# Altium schematic sheet 02-MCU.SchDoc
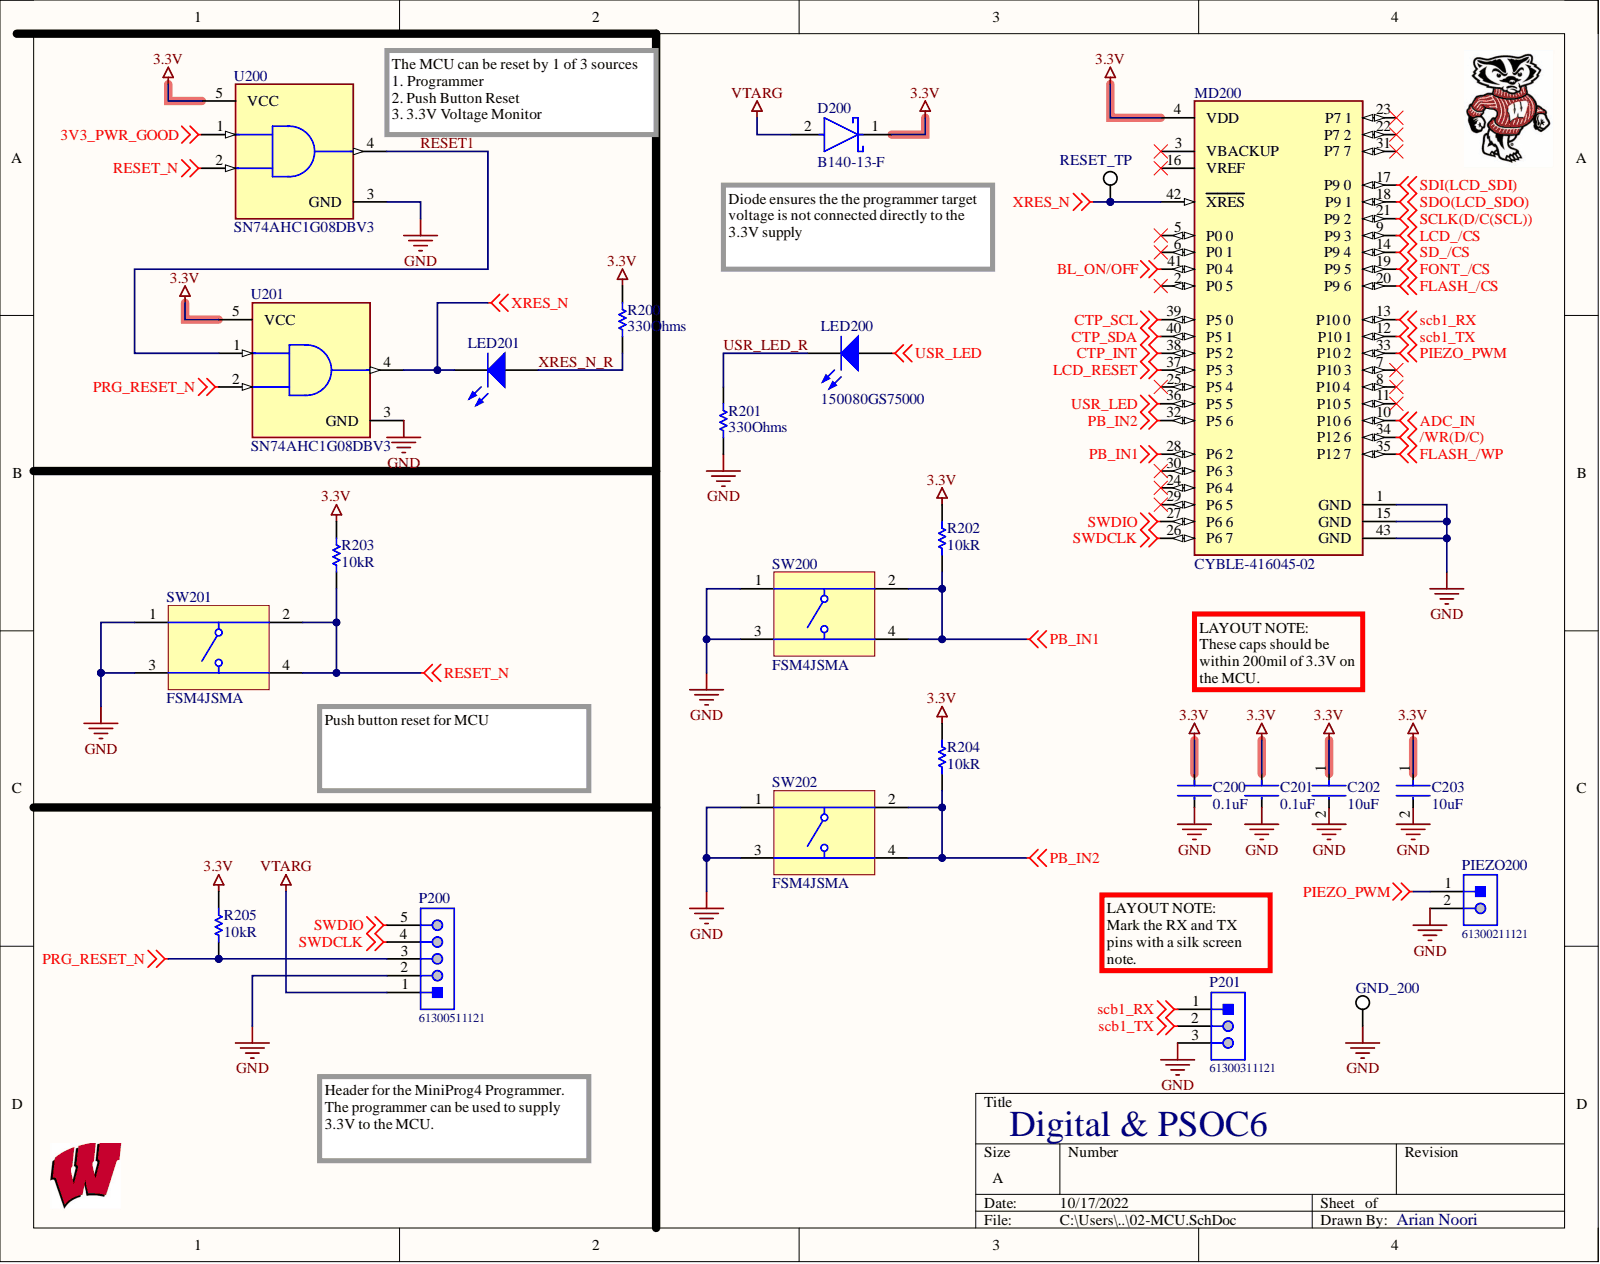
[diagram p0: 02-MCU.SchDoc]

## Parts
C200  0.1uF  [FP-CL21-IPC_C]
C201  0.1uF  [FP-CL21-IPC_C]
C202  value 10uF  Capacitance: 10uF  [FP-C0805C-DG-MFG]  (hidden comment: C0805C106K8PACTU)
C203  value 10uF  Capacitance: 10uF  [FP-C0805C-DG-MFG]  (hidden comment: C0805C106K8PACTU)
D200  B140-13-F  [FP-SMA-MFG]
GND_200  5019  [KSTN-5019_V]  (value not drawn)
LED200  150080GS75000  [0805_A]
LED201  150080RS75000  [0805_A]  (value not drawn)
MD200  CYBLE-416045-02  [FP-CYBLE-416045-02-MFG]
P200  61300511121  [61300511121]
P201  61300311121  [61300311121]
PIEZO200  61300211121  [61300211121]
R200  Resistance: 330Ohms  [RESC2013X60X35LL10T20]  (hidden comment: RC0805FR-07330RL)
R201  Resistance: 330Ohms  [RESC2013X60X35LL10T20]  (hidden comment: RC0805FR-07330RL)
R202  Resistance: 10kR  [RESC2013X60X35NL10T20]  (hidden comment: RC0805FR-0710KL)
R203  Resistance: 10kR  [RESC2013X60X35NL10T20]  (hidden comment: RC0805FR-0710KL)
R204  Resistance: 10kR  [RESC2013X60X35NL10T20]  (hidden comment: RC0805FR-0710KL)
R205  Resistance: 10kR  [RESC2013X60X35NL10T20]  (hidden comment: RC0805FR-0710KL)
RESET_TP  5019  [KSTN-5019_V]  (value not drawn)
SW200  FSM4JSMA  [TECO-FSM4JSMA_V]
SW201  FSM4JSMA  [TECO-FSM4JSMA_V]
SW202  FSM4JSMA  [TECO-FSM4JSMA_V]
U200  SN74AHC1G08DBV3  [FP-DBV0005A-IPC_C]
U201  SN74AHC1G08DBV3  [FP-DBV0005A-IPC_C]

## Nets
3.3V: C200.1 C201.1 C202.1 C203.1 D200.1 MD200.4(VDD) R200.2 R202.2 R203.2 R204.2 R205.2 U200.5(VCC) U201.5(VCC)
3V3_PWR_GOOD: U200.1
/WR(D/C): MD200.34(P12_6)
ADC_IN: MD200.10(P10_6)
BL_ON/OFF: MD200.41(P0_4)
CTP_INT: MD200.38(P5_2)
CTP_SCL: MD200.39(P5_0)
CTP_SDA: MD200.40(P5_1)
FLASH_/CS: MD200.20(P9_6)
FLASH_/WP: MD200.35(P12_7)
FONT_/CS: MD200.19(P9_5)
GND: C200.2 C201.2 C202.2 C203.2 GND_200.1 MD200.1(GND) MD200.15(GND) MD200.43(GND) P200.2 P201.3 PIEZO200.2 R201.1 SW200.1 SW200.3 SW201.1 SW201.3 SW202.1 SW202.3 U200.3(GND) U201.3(GND)
LCD_/CS: MD200.9(P9_3)
LCD_RESET: MD200.37(P5_3)
PB_IN1: MD200.28(P6_2) R202.1 SW200.2 SW200.4
PB_IN2: MD200.32(P5_6) R204.1 SW202.2 SW202.4
PIEZO_PWM: MD200.33(P10_2) PIEZO200.1
PRG_RESET_N: P200.3 R205.1 U201.2
RESET_N: R203.1 SW201.2 SW201.4 U200.2
scb1_RX: MD200.13(P10_0) P201.1
scb1_TX: MD200.12(P10_1) P201.2
SCLK(D/C(SCL)): MD200.21(P9_2)
SD_/CS: MD200.14(P9_4)
SDI(LCD_SDI): MD200.17(P9_0)
SDO(LCD_SDO): MD200.18(P9_1)
SWDCLK: MD200.26(P6_7) P200.4
SWDIO: MD200.27(P6_6) P200.5
USR_LED: LED200.2 MD200.36(P5_5)
VTARG: D200.2 P200.1
XRES_N: LED201.1 MD200.42(XRES) RESET_TP.1 U201.4
RESET1: U200.4 U201.1
USR_LED_R: LED200.1 R201.2
XRES_N_R: LED201.2 R200.1

Not connected: MD200 2(P0_5) 3(VBACKUP) 5(P0_0) 6(P0_1) 7(P10_3) 8(P10_4) 11(P10_5) 16(VREF) 22(P7_2) 23(P7_1) 24(P6_4) 25(P5_4) 29(P6_5) 30(P6_3) 31(P7_7)

## Library data of the parts
C200 C201 = CMP-2000-05017-2: CL21 Series 0805 100nF 50V ±10% Tolerance X7R Multilayer Ceramic Chip Capacitor; Manufacturer: Samsung; Manufacturer Part Number: CL21B104KBCNNNC; Capacitance: 100nF; Case Code (Imperial): 0805; Case Code (Metric): 2012; Case/Package: 0805; Category: Ceramic Capacitors; Component Kind: Standard; ComponentLink1Description: https://datasheet.ciiva.com/25710/cl21b104kbcnnnc-25710182.pdf?src-supplier=Digi-Key; ComponentLink1URL: https://datasheet.ciiva.com/25710/cl21b104kbcnnnc-25710182.pdf?src-supplier=Digi-Key; ComponentLink2Description: https://datasheet.ciiva.com/29199/454152-da-01-en-vs-kondensator-0805-29199814.pdf?src-supplier=Conrad; ComponentLink2URL: https://datasheet.ciiva.com/29199/454152-da-01-en-vs-kondensator-0805-29199814.pdf?src-supplier=Conrad; Construction: Flat; Contact Plating: Tin; Depth: 1.25mm; Dielectric: X7R; Height: 0.85mm; Lead Free: Lead Free; Length: 2mm; Library Name: Altium Content Vault; Material: Ceramic; Max Operating Temperature: 125°C; Min Operating Temperature: -55°C; Mount: Surface Mount; Packaging: Tape and Reel; Pricing 1: 1=0.10000, 10=0.04400, 50=0.02400, 100=0.01960, 500=0.01404, 1000=0.01103 (USD); Radiation Hardening: No; RoHS: Yes; RoHS Compliant: Yes; Series: CL; Stock 1: 4599648; Supplier 1: Digi-Key; Thickness: 0.037inch; Tolerance: 10%; Voltage: 50V; Voltage Rating: 50V; Voltage Rating (DC): 50V; Width: 0.049inch
C202 C203 = CMP-2007-03243-2: CAP CER 10UF 10V X5R 0805; 3DS Part Number: 220-00001; Manufacturer: Kemet; Dielectric Material: Ceramic; Dissipation Factor: 10%; Temperature Coefficient: 15%; Automotive: No; Case Code (Imperial): 0805; Case Code (Metric): 2012; Case/Package: 0805; Category: Cap; Component Kind: Standard; ComponentLink2Description: Datasheet; ComponentLink2URL: https://content.kemet.com/datasheets/KEM_C1006_X5R_SMD.pdf; Construction: Flat; Contact Plating: Tin,Matte; Depth: 1.25mm; Device Class L1: Passive Components; Device Class L2: Capacitors; Device Class L3: Ceramic Capacitors; Dielectric: X5R; Digikey Description: CAP CER 10UF 10V X5R 0805; Height: 1.4mm; Ipc Land Pattern Name: CAPC200125X125; Lead Free: Yes; Length: 2mm; Library Name: Altium Content Vault; Manufacturer 1: KEMET; Manufacturer Part Number: C0805C106K8PACTU; Manufacturer Part Number 1: C0805C106K8PACTU; Material: Ceramic; Max Operating Temperature: 85°C; Min Operating Temperature: -55°C; Mount: Surface Mount; Mouser Description: CAP CER 10UF 10V X5R 0805; Number of Pins: 2; Octopart: https://octopart.com/c0805c106k8pactu-kemet-98697; Package: C0805C-DG; Package Quantity: 1; Packaging: Tape and Reel; Pricing 1: 1=0.14000, 10=0.10300, 50=0.05840, 100=0.04830, 500=0.03388, 1000=0.02805 (USD); Radiation Hardening: No; REACH SVHC: No SVHC; RoHS: TRUE; RoHS Compliant: Yes; Series: C; Stock 1: 8631846; Supplier 1: Digi-Key; Supplier Part Number 1: 399-C0805C106K8PAC7800CT-ND; Temperature: -55°C to +85°C; Temperature Characteristic: X5R; Temperature Range High: +85°C; Temperature Range Low: -55°C; Termination: SMD/SMT; Thickness: 0.055inch; Tolerance: 10%; Voltage: 10V; Voltage Rating: 10V; Voltage Rating (DC): 10V; Width: 1.2mm
D200 = CMP-2000-05096-2: DIODE SCHOTTKY 40V 1A SMA; Manufacturer 1: Diodes; Capacitance: 110pF; Element Configuration: Single; Forward Voltage: 500mV; Max Forward Surge Current (Ifsm): 30A; Max Reverse Current: 500uA; Polarity: Standard; Average Rectified Current: 1A; Case/Package: SMA; Category: Rectifier Diodes; Component Kind: Standard; ComponentLink1Description: https://datasheet.ciiva.com/15220/getdatasheetpartid-115924-15220197.pdf?src-supplier=Verical; ComponentLink1URL: https://datasheet.ciiva.com/15220/getdatasheetpartid-115924-15220197.pdf?src-supplier=Verical; ComponentLink2Description: https://datasheet.ciiva.com/912/ds13002-912361.pdf?src-supplier=Digi-Key; ComponentLink2URL: https://datasheet.ciiva.com/912/ds13002-912361.pdf?src-supplier=Digi-Key; ComponentLink3Description: https://datasheet.ciiva.com/912/ds13002-912362.pdf?src-supplier=Digi-Key; ComponentLink3URL: https://datasheet.ciiva.com/912/ds13002-912362.pdf?src-supplier=Digi-Key; ComponentLink4Description: https://datasheet.ciiva.com/912/ds13002-912360.pdf?src-supplier=Digi-Key; ComponentLink4URL: https://datasheet.ciiva.com/912/ds13002-912360.pdf?src-supplier=Digi-Key; Contact Plating: Tin; Current Rating: 1A; Forward Current: 1A; Height: 2.1mm; Lead Free: Lead Free; Length: 4.6mm; Library Name: Altium Content Vault; Manufacturer Part Number 1: B140-13-F; Max Operating Temperature: 150°C; Max Repetitive Reverse Voltage (Vrrm): 40V; Max Reverse Voltage (DC): 40V; Min Operating Temperature: -65°C; Mount: Surface Mount; Number of Pins: 2; Output Current: 1A; Package Quantity: 5000; Packaging: Cut Tape; Peak Non-Repetitive Surge Current: 30A; Pricing 1: 1=0.41000, 10=0.33200, 100=0.17600, 500=0.11576, 1000=0.07872, 2000=0.07100 (USD); Radiation Hardening: No; REACH SVHC: No SVHC; RoHS: Yes; RoHS Compliant: Yes; Series: B140; Stock 1: 157255; Supplier 1: Digi-Key; Supplier Part Number 1: B140-FDICT-ND; Termination: SMD/SMT; Voltage Rating (DC): 40V; Weight: 0.004233oz; Width: 2.92mm
GND_200 RESET_TP = CMP-1672-00008-1: Test Point, 1 Position SMD, RoHS, Tape and Reel; Manufacturer 1: Keystone Electronics; Category: Connectors; Component Kind: Standard; ComponentLink1Description: Manufacturer URL; ComponentLink1URL: http://www.keyelco.com/; ComponentLink2Description: Datasheet; ComponentLink2URL: http://www.keyelco.com/product-pdf.cfm?p=1357; ComponentLink3Description: https://datasheet.ciiva.com/16318/m60-2p61-16318395.pdf?src-supplier=Future+Electronics; ComponentLink3URL: https://datasheet.ciiva.com/16318/m60-2p61-16318395.pdf?src-supplier=Future+Electronics; ComponentLink4Description: https://datasheet.ciiva.com/16885/70182998-16885945.pdf?src-supplier=Allied+Electronics; ComponentLink4URL: https://datasheet.ciiva.com/16885/70182998-16885945.pdf?src-supplier=Allied+Electronics; ComponentLink5Description: https://datasheet.ciiva.com/14848/m60-2p61-14848437.pdf?src-supplier=Digi-Key; ComponentLink5URL: https://datasheet.ciiva.com/14848/m60-2p61-14848437.pdf?src-supplier=Digi-Key; ComponentLink6Description: https://datasheet.ciiva.com/14848/m60-2p61-14848438.pdf?src-supplier=Digi-Key; ComponentLink6URL: https://datasheet.ciiva.com/14848/m60-2p61-14848438.pdf?src-supplier=Digi-Key; ComponentLink7Description: https://datasheet.ciiva.com/14848/m60-2p61-14848439.pdf?src-supplier=Digi-Key; ComponentLink7URL: https://datasheet.ciiva.com/14848/m60-2p61-14848439.pdf?src-supplier=Digi-Key; Contact Material: Bronze; Contact Plating: Silver; DatasheetVersion: 03/2014; Height: 0.09inch; Lead Free: Lead Free; Library Name: Altium Content Vault; Manufacturer Part Number 1: 5019; Material: Bronze; Mount: Surface Mount; Mounting Technology: Surface Mount; Number of Pins: 1; Package Quantity: 1000; PackageDescription: 1-Pin Surface Mount Device, Body 3.81 x 2.03 mm; PackageReference: 5019; Packaging: Tape and Reel; Pins: 1; Pricing 1: 1=0.34000, 25=0.27360, 100=0.22340, 250=0.20064, 500=0.19152 (USD); REACH SVHC: No SVHC; RoHS: Yes; RoHS Compliant: Yes; RoHSCompliant: True; Series: 5019; Stock 1: 1316816; Supplier 1: Digi-Key; Supplier Part Number 1: 36-5019CT-ND; Termination: SMD/SMT
LED200 = CMP-1426-00007-1: SMD mono-color Chip LED, WL-SMCW, Green; Manufacturer: Wuerth Elektronik; Manufacturer 1: Wurth Electronics; Dominant Wavelength: 520nm; Forward Current: 30mA; Forward Voltage: 3.2V; Lens Style: Clear,Rectangular; Luminous Intensity: 450mcd; Test Current: 20mA; Viewing Angle: 140°; Case Code (Imperial): 0805; Case Code (Metric): 2012; Case/Package: 0805; Category: LEDs; Color: Green; Component Kind: Standard; ComponentLink1Description: Manufacturer URL; ComponentLink1URL: http://www.we-online.com; ComponentLink2Description: Datasheet; ComponentLink2URL: http://katalog.we-online.com/led/datasheet/150080GS75000.pdf; ComponentLink3Description: https://datasheet.ciiva.com/29563/150080gs75000-29563008.pdf?src-supplier=Digi-Key; ComponentLink3URL: https://datasheet.ciiva.com/29563/150080gs75000-29563008.pdf?src-supplier=Digi-Key; ComponentLink4Description: https://datasheet.ciiva.com/29279/759434-da-01-en-chipled-0805-w-kl-gruen-150080gs75000-29279039.pdf?src-supplier=Conrad; ComponentLink4URL: https://datasheet.ciiva.com/29279/759434-da-01-en-chipled-0805-w-kl-gruen-150080gs75000-29279039.pdf?src-supplier=Conrad; Height: 0.7mm; Length: 2mm; Library Name: Altium Content Vault; Manufacturer Part Number 1: 150080GS75000; Max Operating Temperature: 85°C; Min Operating Temperature: -40°C; Mount: Surface Mount; Package Quantity: 4000; PackageReference: 0805_A; Packaging: Cut Tape; PartNumber: 150080GS75000; Pricing 1: 1=0.19000, 50=0.17600, 100=0.15100, 500=0.13100 (USD); REACH SVHC: Unknown; RoHS: Yes; RoHS Compliant: Yes; Stock 1: 95801; Supplier 1: Digi-Key; Supplier Part Number 1: 732-4983-1-ND; Wavelength: 520nm; Width: 1.25mm
LED201 = CMP-1426-00011-1: SMD mono-color Chip LED, WL-SMCW, Red; Manufacturer: Wuerth Elektronik; Manufacturer 1: Wurth Electronics; Dominant Wavelength: 625nm; Forward Current: 30mA; Forward Voltage: 2V; Lens Style: Clear,Rectangular; Luminous Intensity: 150mcd; Test Current: 20mA; Viewing Angle: 140°; Case Code (Imperial): 0805; Case Code (Metric): 2012; Case/Package: 0805; Category: LEDs; Color: Red; Component Kind: Standard; ComponentLink1Description: Manufacturer URL; ComponentLink1URL: http://www.we-online.com; ComponentLink2Description: Datasheet; ComponentLink2URL: http://katalog.we-online.com/led/datasheet/150080RS75000.pdf; ComponentLink3Description: https://datasheet.ciiva.com/22656/150080rs75000-22656961.pdf?src-supplier=Element14; ComponentLink3URL: https://datasheet.ciiva.com/22656/150080rs75000-22656961.pdf?src-supplier=Element14; ComponentLink4Description: https://datasheet.ciiva.com/29279/759435-da-01-en-chipled-0805-w-kl-rot-150080rs75000-29279032.pdf?src-supplier=Conrad; ComponentLink4URL: https://datasheet.ciiva.com/29279/759435-da-01-en-chipled-0805-w-kl-rot-150080rs75000-29279032.pdf?src-supplier=Conrad; Height: 0.7mm; Length: 2mm; Library Name: Altium Content Vault; Manufacturer Part Number 1: 150080RS75000; Max Operating Temperature: 85°C; Min Operating Temperature: -40°C; Mount: Surface Mount; Package Quantity: 4000; PackageReference: 0805_A; Packaging: Tape and Reel; PartNumber: 150080RS75000; Pricing 1: 1=0.19000, 50=0.17600, 100=0.15100, 500=0.13100 (USD); REACH SVHC: Unknown; RoHS: Yes; RoHS Compliant: Yes; Stock 1: 100282; Supplier 1: Digi-Key; Supplier Part Number 1: 732-4984-1-ND; Wavelength: 625nm; Width: 1.25mm
MD200 = CMP-12964-000002-1: RF TXRX MOD BLUETOOTH TRACE ANT; Manufacturer: Cypress Semiconductor; Manufacturer 1: Cypress; Bandwidth: 100MHz; Data Rate: 2Mbits/s; Interface: I2C,SPI,UART,I2S,Other; Max Frequency: 2.5GHz; Max Supply Voltage: 3.6V; Min Supply Voltage: 1.71V; Number Of Adc Channels: 1; Number Of Gpio: 36; Receiving Current: 6.7mA; Transmitting Current: 5.7mA; Automotive: No; Category: UNK; Component Kind: Standard; ComponentLink2Description: Datasheet; ComponentLink2URL: https://www.cypress.com/file/428336/download; Device Class L1: Integrated Circuits (ICs); Device Class L2: RF Semiconductors and Devices; Device Class L3: Transceivers; Digikey Description: RF TXRX MOD BLUETOOTH TRACE ANT; Height: 2mm; Lead Free: Yes; Library Name: Altium Content Vault; Manufacturer Part Number: CYBLE-416045-02; Manufacturer Part Number 1: CYBLE-416045-02; Octopart: https://octopart.com/cyble-416045-02-cypress+semiconductor-96386825; Package: 0; Pricing 1: 1=16.24000, 10=14.69300, 25=13.53280, 100=12.37280, 250=11.59952 (USD); RoHS: TRUE; RoHS Compliant: Yes; Stock 1: 3441; Supplier 1: Digi-Key; Supplier Part Number 1: 428-4622-1-ND; Temperature: -40°C to +85°C; Temperature Range High: +85°C; Temperature Range Low: -40°C
P200 = CMP-1502-01068-1: THT Vertical Pin Header WR-PHD, Pitch 2.54 mm, Single Row, 5 pins; Manufacturer: Wurth Elektronik; Manufacturer 1: Wurth Electronics; Connector Type: Male Pin; Contact Resistance: 20mOhm; Gender: Male; Number of Contacts: 5; Pitch: 2.54mm; Rated Current: 3A; Withstanding Voltage: 500VAC; Working Voltage: 250VAC; Category: Headers and Wire Housings; Color: Black; Component Kind: Standard; ComponentLink1Description: http://www.farnell.com/cad/1737268.pdf?src-supplier=Element14; ComponentLink1URL: http://www.farnell.com/cad/1737268.pdf?src-supplier=Element14; ComponentLink2Description: https://katalog.we-online.de/em/datasheet/6130xx11121.pdf?src-supplier=Digi-Key; ComponentLink2URL: https://katalog.we-online.de/em/datasheet/6130xx11121.pdf?src-supplier=Digi-Key; Contact Material: Copper; Contact Mating Length: 0.236inch; Contact Plating: Gold; Datasheet URL: https://katalog.we-online.de/em/datasheet/6130xx11121.pdf; Lead Free: Lead Free; Library Name: Altium Content Vault; Manufacturer Part Number 1: 61300511121; Manufacturer URL: http://www.we-online.de; Mount: Through Hole; Mounting Technology: THT; Number of Positions: 5; Number of Rows: 1; Operating Temperature: -40to105degC; Orientation: Vertical; Package Reference: 61300511121; Packaging: Bag; Part Number: 61300511121; Pin Number: 5; Pricing 1: 1=0.26000, 10=0.22200, 25=0.18520, 50=0.15500, 100=0.14100, 1000=0.12800 (USD); REACH SVHC: Unknown; RoHS: Yes; RoHS Compliant: Yes; Row Number: Single; Stock 1: 41302; Supplier 1: Digi-Key; Supplier Part Number 1: 732-5318-ND; Termination: Solder; Publisher: WurthElektronik
P201 = CMP-1502-01062-1: CONN HEADER 3 POS 2.54; Manufacturer: Wurth Elektronik; Connector Type: Male Pin; Contact Resistance: 20mOhm; Gender: Male; Number of Contacts: 3; Pitch: 2.54mm; Rated Current: 3A; Row Number: Single; Withstanding Voltage: 500VAC; Working Voltage: 250VAC; Category: Connectors; Color: Black; Component Kind: Standard; ComponentLink1Description: http://www.farnell.com/cad/1737268.pdf?src-supplier=Element14; ComponentLink1URL: http://www.farnell.com/cad/1737268.pdf?src-supplier=Element14; ComponentLink2Description: https://katalog.we-online.de/em/datasheet/6130xx11121.pdf?src-supplier=Digi-Key; ComponentLink2URL: https://katalog.we-online.de/em/datasheet/6130xx11121.pdf?src-supplier=Digi-Key; Contact Material: Copper; Contact Mating Length: 0.236inch; Contact Plating: Gold; Datasheet URL: https://katalog.we-online.de/em/datasheet/6130xx11121.pdf; Lead Free: Lead Free; Library Name: Altium Content Vault; Manufacturer Part Number: 61300311121; Manufacturer URL: http://www.we-online.de; Mount: Through Hole; Mounting Technology: THT; Number of Positions: 3; Number of Rows: 1; Operating Temperature: -40to105degC; Orientation: Vertical; Package Reference: 61300311121; Packaging: Bag; Part Number: 61300311121; Pin Number: 3; Pricing 1: 1=0.13000, 10=0.11300, 25=0.09400, 50=0.07900, 100=0.07100, 1000=0.06600 (USD); REACH SVHC: Unknown; RoHS: Yes; RoHS Compliant: Yes; Stock 1: 76429; Supplier 1: Digi-Key; Termination: Solder; Publisher: WurthElektronik
PIEZO200 = CMP-1712-00002-4: THT Vertical Pin Header WR-PHD, Pitch 2.54 mm, Single Row, 2 pins; Manufacturer: Wurth Elektronik; Connector Type: Male Pin; Contact Resistance: 20mOhm; Current Rating: 3A; Gender: Male; Number of Contacts: 2; Pitch: 2.54mm; Voltage Rating: 250V; Withstanding Voltage: 500VAC; Working Voltage: 250VAC; Category: Headers and Wire Housings; Color: Black; Component Kind: Standard; ComponentLink1Description: https://datasheet.ciiva.com/13619/6130xx11121-48870-13619345.pdf?src-supplier=Mouser; ComponentLink1URL: https://datasheet.ciiva.com/13619/6130xx11121-48870-13619345.pdf?src-supplier=Mouser; Contact Gender: Male; Contact Material: Copper Alloy,Copper; Contact Mating Length: 0.236inch; Contact Plating: Gold; Contact Type: Male Pin; Datasheet URL: https://katalog.we-online.de/em/datasheet/6130xx11121.pdf; Housing Material: PBT; Lead Free: Lead Free; Library Name: Altium Content Vault; Manufacturer Part Number: 61300211121; Manufacturer URL: http://www.we-online.de; Max Operating Temperature: 125°C; Min Operating Temperature: -40°C; Mount: Through Hole; Mounting Technology: THT; Number of Positions: 2; Number of Rows: 1; Operating Temperature: -40to105degC; Orientation: Vertical; Package Reference: 61300211121; Packaging: Bag; Part Number: 61300211121; Pin Number: 2; Pricing 1: 1=0.13000, 10=0.11300, 25=0.09400, 50=0.07900, 100=0.07100, 1000=0.06500 (USD); Rated Current: 3A; REACH SVHC: Unknown; RoHS: Yes; RoHS Compliant: Yes; Row Number: Single; Series: WR-PHD; Stock 1: 267961; Supplier 1: Digi-Key; Termination: Through Hole; Publisher: WurthElektronik
R200 R201 = CMP-2000-06960-1: Chip Resistor, 330 Ohm, +/- 1%, 125 mW, -55 to 155 degC, 0805 (2012 Metric), RoHS, Tape and Reel; Manufacturer: Yageo; Max Power Dissipation: 125mW; Power Rating: 125mW(1/8W); Temperature Coefficient: 100PPM/C; Voltage Rating: 150V; Case Code (Imperial): 0805; Case Code (Metric): 2012; Case Code - in: 0805; Case Code - mm: 2012; Case/Package: 0805; Category: Chip SMD Resistors; Component Kind: Standard; ComponentLink1Description: https://datasheet.ciiva.com/2457/pyu-r-int-thick-7-2457015.pdf?src-supplier=Digi-Key; ComponentLink1URL: https://datasheet.ciiva.com/2457/pyu-r-int-thick-7-2457015.pdf?src-supplier=Digi-Key; ComponentLink2Description: https://datasheet.ciiva.com/2457/pyu-r-int-thick-7-2457016.pdf?src-supplier=Digi-Key; ComponentLink2URL: https://datasheet.ciiva.com/2457/pyu-r-int-thick-7-2457016.pdf?src-supplier=Digi-Key; ComponentLink3Description: https://datasheet.ciiva.com/2457/pyu-r-int-thick-7-2457014.pdf?src-supplier=Digi-Key; ComponentLink3URL: https://datasheet.ciiva.com/2457/pyu-r-int-thick-7-2457014.pdf?src-supplier=Digi-Key; ComponentLink4Description: https://datasheet.ciiva.com/12667/rc0805-12667925.pdf?src-supplier=Mouser; ComponentLink4URL: https://datasheet.ciiva.com/12667/rc0805-12667925.pdf?src-supplier=Mouser; ComponentLink5Description: https://datasheet.ciiva.com/7941/444164-7941768.pdf?src-supplier=Element14; ComponentLink5URL: https://datasheet.ciiva.com/7941/444164-7941768.pdf?src-supplier=Element14; ComponentLink6Description: https://datasheet.ciiva.com/7941/444164-7941769.pdf?src-supplier=Element14; ComponentLink6URL: https://datasheet.ciiva.com/7941/444164-7941769.pdf?src-supplier=Element14; ComponentLink7Description: https://datasheet.ciiva.com/16740/rc0805-16740975.pdf?src-supplier=Future+Electronics; ComponentLink7URL: https://datasheet.ciiva.com/16740/rc0805-16740975.pdf?src-supplier=Future+Electronics; Construction: Rectangular; Datasheet URL: http://www.yageo.com/documents/recent/PYu-RC_Group_51_RoHS_L_7.pdf; Datasheet Version: Rev. 7, 05/2017; Depth: 1.25mm; Features: Moisture Resistant; Height: 0.024inch; Lead Free: Lead Free; Length: 2mm; Library Name: Altium Content Vault; Manufacturer 1: Yageo; Manufacturer Part Number 1: RC0805FR-07330RL; Manufacturer URL: http://www.yageo.com/; Max Operating Temperature: 155°C; Military Standard: Not; Min Operating Temperature: -55°C; Mounting Technology: SurfaceMount; Number of Terminations: 2; Operating Temperature Range: -55Cto+155C; Package Description: 2-Pin Surface Mounted Device, Body 2 x 1.25 mm; Package Quantity: 5000; Package Reference: 0805; Packaging: Reel; Pricing 1: 1=0.10000, 10=0.03800, 100=0.01560, 1000=0.00699, 2500=0.00607 (USD); Product: ThickFilmResistorsGeneralPurpose; REACH SVHC: Unknown; Resistance Tolerance: 1%; Resistor Type: Thick Film; RoHS: Yes; RoHS Compliant: Yes; Series: RC; Size Code: 0805; Stock 1: 1406392; Supplier 1: Digi-Key; Supplier Part Number 1: 311-330CRCT-ND; Termination: SMD/SMT; Termination Style: SMD/SMT; Tolerance: 1%; Width: 0.049inch
R202-R205 = CMP-1659-00013-4: Chip Resistor, 10 KOhm, +/- 1%, 0.125 W, -55 to 155 degC, 0805 (2012 Metric), RoHS, Tape and Reel; Manufacturer: Yageo; Max Power Dissipation: 125mW; Temperature Coefficient: 100ppm/°C; Voltage Rating: 150 V; Case Code (Imperial): 0805; Case Code (Metric): 2012; Case/Package: 0805; Category: Chip SMD Resistors; Component Kind: Standard; ComponentLink1Description: Manufacturer URL; ComponentLink1URL: http://www.yageo.com/; ComponentLink2Description: Datasheet; ComponentLink2URL: http://www.yageo.com/documents/recent/PYu-RC_Group_51_RoHS_L_04.pdf; ComponentLink3Description: https://datasheet.ciiva.com/11808/0900766b8106beab-11808151.pdf?src-supplier=RSComponents; ComponentLink3URL: https://datasheet.ciiva.com/11808/0900766b8106beab-11808151.pdf?src-supplier=RSComponents; ComponentLink4Description: https://datasheet.ciiva.com/46447/444164-46447563.pdf?src-supplier=Element14; ComponentLink4URL: https://datasheet.ciiva.com/46447/444164-46447563.pdf?src-supplier=Element14; ComponentLink5Description: https://datasheet.ciiva.com/2456/pyu-r-int-thick-7-2456973.pdf?src-supplier=Digi-Key; ComponentLink5URL: https://datasheet.ciiva.com/2456/pyu-r-int-thick-7-2456973.pdf?src-supplier=Digi-Key; ComponentLink6Description: https://datasheet.ciiva.com/2456/pyu-r-int-thick-7-2456974.pdf?src-supplier=Digi-Key; ComponentLink6URL: https://datasheet.ciiva.com/2456/pyu-r-int-thick-7-2456974.pdf?src-supplier=Digi-Key; ComponentLink7Description: https://datasheet.ciiva.com/2456/pyu-r-int-thick-7-2456972.pdf?src-supplier=Digi-Key; ComponentLink7URL: https://datasheet.ciiva.com/2456/pyu-r-int-thick-7-2456972.pdf?src-supplier=Digi-Key; ComponentLink8Description: https://datasheet.ciiva.com/12669/rc0805-12669688.pdf?src-supplier=Mouser; ComponentLink8URL: https://datasheet.ciiva.com/12669/rc0805-12669688.pdf?src-supplier=Mouser; ComponentLink9Description: https://datasheet.ciiva.com/16747/rc0805-16747087.pdf?src-supplier=Future+Electronics; ComponentLink9URL: https://datasheet.ciiva.com/16747/rc0805-16747087.pdf?src-supplier=Future+Electronics; Construction: Rectangular; DatasheetVersion: Rev. 4, 02/2016; Depth: 1.25mm; Features: Moisture Resistant; Height: 0.5 mm; Lead Free: Lead Free; Length: 2 mm; Library Name: Altium Content Vault; Manufacturer 1: Yageo; Manufacturer Part Number 1: RC0805FR-0710KL; Max Operating Temperature: 155 degC; Military Standard: Not; Min Operating Temperature: -55 degC; Mount: Surface Mount; Mounting Technology: Surface Mount; Number of Terminations: 2; Package Quantity: 5000; PackageDescription: 2-Pin Surface Mounted Device, Body 2 x 1.25 mm; PackageReference: 0805; Packaging: Tape and Reel; Pins: 2; Power Rating: 125mW; Pricing 1: 1=0.10000, 10=0.03800, 100=0.01560, 1000=0.00702, 2500=0.00610 (USD); REACH SVHC: No SVHC; Resistance Tolerance: 1%; Resistor Type: Thick Film; RoHS: Yes; RoHS Compliant: Yes; RoHSCompliant: true; Series: RC; Size Code: 0805; Stock 1: 5682818; Supplier 1: Digi-Key; Supplier Part Number 1: 311-10.0KCRCT-ND; Technology: THICK FILM; Termination: SMD/SMT; Tolerance: 1%; Width: 0.049inch
SW200-SW202 = CMP-2000-05602-2: Swicth, SPST, 24 V, -35 to 85 degC, 4-Pin SMD, RoHS, Tape and Reel; Manufacturer: TE Connectivity; Actuator Length: 0.055inch; Actuator Material: Polyamide; Actuator Type: Standard; Case\/Package: SMD/SMT; Contact Current Rating: 50mA; Contact Resistance: 100mOhm; Electrical Life: 100000Cycles; Illumination Type: Illuminated; Insulation Resistance: 100MR; Max Voltage Rating (DC): 12V; Operating Force: 1.57N; Switch Function: Off-Mom; Switch Travel: 0.25mm; Voltage Rating: 24V; Case/Package: SMD/SMT; Category: Pushbutton Switches; Color: Black; Component Kind: Standard; Contact Material: CopperAlloy; Contact Plating: Silver; Current Rating: 50mA; Datasheet URL: http://www.te.com/commerce/DocumentDelivery/DDEController?Action=showdoc&DocId=Customer+Drawing%7F2-1437565-7%7FV%7Fpdf%7FEnglish%7FENG_CD_2-1437565-7_V.pdf%7F4-1437565-2; Datasheet Version: Rev. V, 02/2015; Depth: 10mm; ELV: Compliant; Lead Free: Lead Free; Length: 6mm; Library Name: Altium Content Vault; Manufacturer 1: TE Connectivity; Manufacturer Part Number 1: FSM4JSMA; Manufacturer URL: http://www.te.com/; Max Current Rating: 50mA; Max Operating Temperature: 85degC; Mechanical Life: 100000Cycles; Min Operating Temperature: -35degC; Mount: SurfaceMount; Mounting Technology: SurfaceMount; Orientation: Straight; Package Description: 4-Pin Surface Mount Device, Body 6 x 6 mm, Pitch 4.5 mm; Package Quantity: 750; Package Reference: FSM4JSMA; Packaging: LoosePiece; Pricing 1: 1=0.27000, 10=0.26300, 25=0.24960, 100=0.22600, 250=0.20604, 750=0.19152, 1500=0.16339, 3000=0.15885, 5250=0.14977 (USD); Radiation Hardening: No; REACH SVHC: Unknown; RoHS: Yes; RoHS Compliant: Yes; RoHSCompliant: true; Series: FSMJSMA; Stock 1: 65608; Supplier 1: Digi-Key; Supplier Part Number 1: 450-1129-ND; Termination: GullWing; Throw Configuration: SPST; Voltage Rating (DC): 24V; Width: 6mm
U200 U201 = CMP-04903-000082-1: IC GATE AND 1CH 2-INP SOT23-5; Manufacturer 1: Texas Instruments; Logic Function: AND GATE; Category: Logic Gates; Component Kind: Standard; ComponentLink1Description: http://www.ti.com/general/docs/suppproductinfo.tsp?distId=10&amp;gotoUrl=http%3A%2F%2Fwww.ti.com%2Flit%2Fgpn%2Fsn74ahc1g08&src-supplier=Digi-Key; ComponentLink1URL: http://www.ti.com/general/docs/suppproductinfo.tsp?distId=10&amp;gotoUrl=http%3A%2F%2Fwww.ti.com%2Flit%2Fgpn%2Fsn74ahc1g08&src-supplier=Digi-Key; Library Name: Altium Content Vault; Manufacturer Part Number 1: SN74AHC1G08DBV3; Pricing 1: 1=0.53000, 10=0.42800, 25=0.39200, 100=0.29170, 250=0.26436, 500=0.21878, 1000=0.16409 (USD); RoHS: Yes; RoHS Compliant: Yes; Stock 1: 11940; Supplier 1: Digi-Key; Supplier Part Number 1: 296-SN74AHC1G08DBV3CT-ND
